# Altium schematic sheet Motor-Y.SchDoc(Motor-Y)
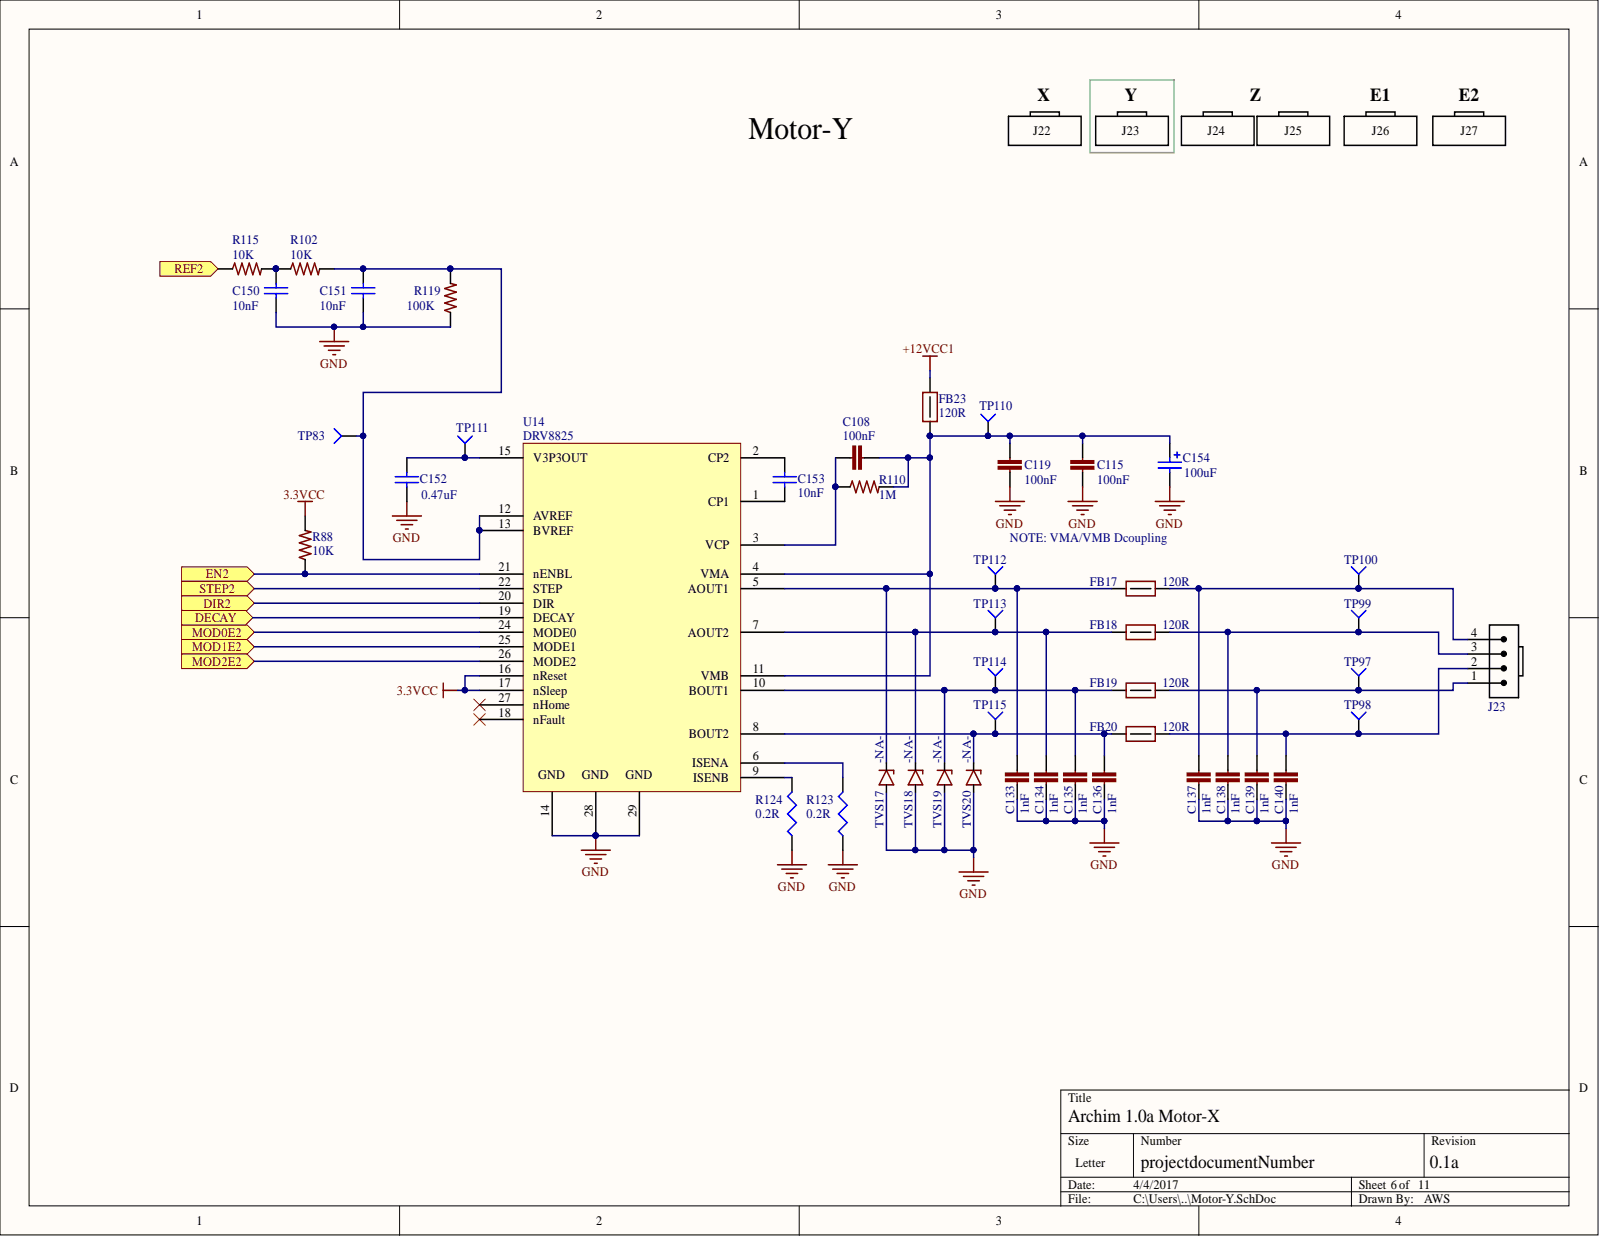
[diagram p0: Motor-Y.SchDoc]

## Parts
C108  100nF  [C0402]
C115  100nF  [C0603]
C119  100nF  [C0603]
C133  1nF  [C0402]
C134  1nF  [C0402]
C135  1nF  [C0402]
C136  1nF  [C0402]
C137  1nF  [C0402]
C138  1nF  [C0402]
C139  1nF  [C0402]
C140  1nF  [C0402]
C150  10nF  [C0402]
C151  10nF  [C0402]
C152  value 0.47uF  [C0402]  (hidden comment: 0402)
C153  10nF  [C0402]
C154  value 100uF  [CAP-ELEC-063063077]  (hidden comment: UWT1V101MCL1GS)
FB17  120R  [FB0603]
FB18  120R  [FB0603]
FB19  120R  [FB0603]
FB20  120R  [FB0603]
FB23  120R  [FB0603]
J23  Molex 0705430003  [4POL254]  (value not drawn)
R88  10K  [R0402]
R102  10K  [R0402]
R110  1M  [R0402]
R115  10K  [R0402]
R119  100K  [R0402]
R123  value 0.2R  [2512R-0.55]  (hidden comment: 2512)
R124  value 0.2R  [2512R-0.55]  (hidden comment: 2512)
TP83  TP4  [TP-2]  (value not drawn)  (no BOM)
TP97  TP4  [TP-2]  (value not drawn)  (no BOM)
TP98  TP4  [TP-2]  (value not drawn)  (no BOM)
TP99  TP4  [TP-2]  (value not drawn)  (no BOM)
TP100  TP4  [TP-2]  (value not drawn)  (no BOM)
TP110  TP4  [TP-2]  (value not drawn)  (no BOM)
TP111  TP4  [TP-2]  (value not drawn)  (no BOM)
TP112  TP4  [TP-2]  (value not drawn)  (no BOM)
TP113  TP4  [TP-2]  (value not drawn)  (no BOM)
TP114  TP4  [TP-2]  (value not drawn)  (no BOM)
TP115  TP4  [TP-2]  (value not drawn)  (no BOM)
TVS17  value -NA-  [SMF]  (hidden comment: TVS 30V SMF)
TVS18  value -NA-  [SMF]  (hidden comment: TVS 30V SMF)
TVS19  value -NA-  [SMF]  (hidden comment: TVS 30V SMF)
TVS20  value -NA-  [SMF]  (hidden comment: TVS 30V SMF)
U14  DRV8825  [DRV8825PWPR]

## Ports
DECAY, DIR2, EN2, MOD0E2, MOD1E2, MOD2E2, REF2, STEP2

## Nets
3.3VCC: R88.2 U14.16(nReset) U14.17(nSleep)
+12VCC1: FB23.2
GND: C115.2 C119.2 C133.2 C134.2 C135.2 C136.2 C137.2 C138.2 C139.2 C140.2 C150.1 C151.1 C152.1 C154.2 R119.1 R123.1 R124.1 TVS17.A TVS18.A TVS19.A TVS20.A U14.14 U14.28 U14.29
DECAY: U14.19(DECAY) ; port DECAY
DIR2: U14.20(DIR) ; port DIR2
EN2: R88.1 U14.21(nENBL) ; port EN2
MOD0E2: U14.24(MODE0) ; port MOD0E2
MOD1E2: U14.25(MODE1) ; port MOD1E2
MOD2E2: U14.26(MODE2) ; port MOD2E2
REF2: R115.1 ; port REF2
STEP2: U14.22(STEP) ; port STEP2
NetC108_1: C108.1 R110.1 U14.3(VCP)
NetC108_2: C108.2 C115.1 C119.1 C154.1 FB23.1 R110.2 TP110.1 U14.4(VMA) U14.11(VMB)
NetC133_1: C133.1 FB17.1 TP112.1 TVS17.K U14.5(AOUT1)
NetC134_1: C134.1 FB18.1 TP113.1 TVS18.K U14.7(AOUT2)
NetC135_1: C135.1 FB19.1 TP114.1 TVS19.K U14.10(BOUT1)
NetC136_1: C136.1 FB20.1 TP115.1 TVS20.K U14.8(BOUT2)
NetC137_1: C137.1 FB17.2 J23.4 TP100.1
NetC138_1: C138.1 FB18.2 J23.3 TP99.1
NetC139_1: C139.1 FB19.2 J23.1 TP97.1
NetC140_1: C140.1 FB20.2 J23.2 TP98.1
NetC150_2: C150.2 R102.1 R115.2
NetC151_2: C151.2 R102.2 R119.2 TP83.1 U14.12(AVREF) U14.13(BVREF)
NetC152_2: C152.2 TP111.1 U14.15(V3P3OUT)
NetC153_1: C153.1 U14.1(CP1)
NetC153_2: C153.2 U14.2(CP2)
NetR123_2: R123.2 U14.6(ISENA)
NetR124_2: R124.2 U14.9(ISENB)

Not connected: U14 18(nFault) 27(nHome)

## Library data of the parts
C108 = GRM155R71C104KA88D: CAP CER 0402 100nF 16V 10% X7R; PART DESCRIPTION: 0.10µF ±10% 16V Ceramic Capacitor X7R 0402 (1005 Metric); PART: 0.1uF 16V X7R 0402; MANUFACTURER: Murata; VALUE: 100nF; TOLERANCE: 10%; Simulation: Model Name; Signal Integrity: Model Name; MANUFACTURER #: GRM155R71C104KA88D; Library Name: 3Delight.SCHLIB; EE_SPEC: 16V; DISTRIBUTOR #: 490-3261-2-ND; DISTRIBUTOR: Digikey; Component Kind: Standard
C115 C119 = C0603C104K5RACTU: CAP CER 0603 100nF 50V 10% X7R; PART DESCRIPTION: 0.10µF ±10% 50V Ceramic Capacitor X7R 0603 (1608 Metric); PART: 100nF 50V X7R 0603; MANUFACTURER: Kemet; VALUE: 100nF; TOLERANCE: 10%; Simulation: Model Name; Signal Integrity: Model Name; MANUFACTURER #: C0603C104K5RACTU; Library Name: 3Delight.SCHLIB; EE_SPEC: 50V; DISTRIBUTOR #: 399-5089-2-ND; DISTRIBUTOR: Digikey; Component Kind: Standard
C133-C140 = CAP 1nF 50V 0402 X7R: 1000pF 50V Ceramic Capacitor X7R 0402 (1005 Metric) 0.039" L x 0.020" W (1.00mm x 0.50mm); PART DESCRIPTION: 1000pF ±10% 50V Ceramic Capacitor X7R 0402 (1005 Metric); PART: 1nF 50V X7R 0402; MANUFACTURER #: GRM155R71H102KA01D; MANUFACTURER: Murata; TOLERANCE: 10%; VALUE: 1nF; Library Name: 3Delight.SCHLIB; EE_SPEC: 50V; DISTRIBUTOR #: 490-1303-2-ND; DISTRIBUTOR: Digikey; Component Kind: Standard
C150 C151 C153 = GRM155R71H103JA88D: CAP CER 10nF 50V X7R 0402; PART DESCRIPTION: 10000pF ±5% 50V Ceramic Capacitor X7R 0402 (1005 Metric); MANUFACTURER: Murata; TOLERANCE: 5%; VALUE: 10nF; Simulation: CAP; Signal Integrity: Cap Semi; PART: 10nF 50V X7R 0402; MANUFACTURER #: GRM155R71H103JA88D; Library Name: Tigershark3D.SchLib; EE_SPEC: 50V; DISTRIBUTOR #: 490-7763-1-ND; DISTRIBUTOR: Digikey; Component Kind: Standard
C152 = GRM155R60J474KE19D: 0.47uF 6.3v C0402 - GRM155R60J474KE19D; PART DESCRIPTION: 0.47µF ±10% 6.3V Ceramic Capacitor X5R 0402 (1005 Metric); PART: 0.47uF 6.3V X5R 0402; MANUFACTURER: Murata; TOLERANCE: 10%; Simulation: CAP; Signal Integrity: Cap Semi; MANUFACTURER #: GRM155R60J474KE19D; Library Name: Tigershark3D.SchLib; EE_SPEC: 6.3V; DISTRIBUTOR #: 490-3266-2-ND; DISTRIBUTOR: Digikey; Component Kind: Standard
C154 = UWT1V101MCL1GS: CAP ALUM 100UF 35V 20% SMD; PART DESCRIPTION: 100µF 35V Aluminum Capacitors Radial, Can - SMD 1000 Hrs @ 105°C; PART: 100uF 35V SMD Al-El; MANUFACTURER: Nichicon; TOLERANCE: 20%; Simulation: CAP; Signal Integrity: Cap Pol; MANUFACTURER #: UWT1V101MCL1GS; Library Name: 3Delight.SCHLIB; EE_SPEC: 35V; DISTRIBUTOR #: 493-2203-2-ND; DISTRIBUTOR: Digikey; Component Kind: Standard
FB17-FB20 FB23 = FERRITE 120R 3A 0603: FERRITE BEAD 120 OHM 0603 1LN; MANUFACTURER #: CIS10P121AC; MANUFACTURER2#: 490-5254-2-ND; MANUFACTURER: Samsung; VALUE: 120Rfb; TOLERANCE: -NA-; EE_SPEC: 3A; PART DESCRIPTION: FERRITE BEAD 120 OHM 0603 1LN; PART: FERRITE 120R 3A 0603; Library Name: 3Delight.SCHLIB; DISTRIBUTOR #: 1276-6351-2-ND; DISTRIBUTOR: Digikey; Component Kind: Standard
J23 = Molex 0705430003 Alt_1: Molex 4 Pin Clip Connector; PART DESCRIPTION: 4 Positions Header, Shrouded Connector 0.100" (2.54mm) Through Hole Tin; PART: 4 Pin Conn; MANUFACTURER #: 0705430038; VALUE: HDR4; TOLERANCE: -NA-; MANUFACTURER: Molex; EE_SPEC: -NA-; DISTRIBUTOR #: WM4826-ND; DISTRIBUTOR: Digikey; Component Kind: Standard; Library Name: Archim.SCHLIB
R88 R102 R115 = RC0402FR-0710KP: RES SMD 10K OHM 1% 1/16W 0402; PART DESCRIPTION: 10k Ohm ±1% 0.063W, 1/16W Chip Resistor 0402 (1005 Metric) Moisture Resistant Thick Film; PART: 10k 1% 0402; MANUFACTURER: Yageo; VALUE: 10K; TOLERANCE: 1%; Simulation: Model Name; Signal Integrity: Model Name; MANUFACTURER #: RC0402FR-0710KP; Library Name: 3Delight.SCHLIB; EE_SPEC: 1/16W; DISTRIBUTOR #: YAG1249TR-ND; DISTRIBUTOR: Digikey; Component Kind: Standard
R110 = ERJ2GEJ105X: RES 0402 1M 100mW 5%; PART DESCRIPTION: 1M Ohm ±5% 0.1W, 1/10W Chip Resistor 0402 (1005 Metric) Automotive AEC-Q200 Thick Film; PART: 1M 5% 0402; MANUFACTURER: Panasonic; EE_SPEC: 1/10W; VALUE: 1M; TOLERANCE: 5%; Simulation: Model Name; Signal Integrity: Model Name; MANUFACTURER #: ERJ2GEJ105X; Library Name: Tigershark3D.SchLib; DISTRIBUTOR #: P1.0MJTR-ND; DISTRIBUTOR: Digikey; Component Kind: Standard
R119 = RC1005F104CS: RES SMD 100K OHM 1% 1/16W 0402; PART DESCRIPTION: 100k Ohm ±1% 0.063W, 1/16W Chip Resistor 0402 (1005 Metric) Moisture Resistant Thick Film; PART: 100k 1% 0402; MANUFACTURER: Samsung; VALUE: 100K; TOLERANCE: 1%; Simulation: Model Name; Signal Integrity: Model Name; MANUFACTURER #: RC1005F104CS; Library Name: Tigershark3D.SchLib; EE_SPEC: 1/16W; DISTRIBUTOR #: 1276-3432-1-ND; DISTRIBUTOR: Digikey; Component Kind: Standard
R123 R124 = CSRN2512FTR200: RES SMD 0.2 OHM 1% 2W 2512; PART DESCRIPTION: 0.2 Ohm ±1% 2W Chip Resistor 2512 (6432 Metric) Current Sense Thick Film; PART: 0.2R 1% 2512; MANUFACTURER #: CSRN2512FKR200; MANUFACTURER: Stackpole ELectronics Inc; TOLERANCE: 1%; Simulation: RESISTOR; Signal Integrity: Res; EE_SPEC: 2W; DISTRIBUTOR #: CSRN2512FKR200TR-ND; DISTRIBUTOR: Digikey; Component Kind: Standard; Library Name: Archim.SCHLIB
TP83 TP97-TP100 TP110-TP115 = Socket: MANUFACTURER #: NOTAPART-Testpoint; Signal Integrity: Connector; Description: Socket; Library Name: Archim.SCHLIB
TVS17-TVS20 = TVS 30V SMF: TVS DIODE 30VWM 48.4VC SMF; PART: TVS Diode 48.4VC; MANUFACTURER #: SMF30A-E3-08; MANUFACTURER: Vishay; EE_SPEC: 30Vrs 48.4Vc; TOLERANCE: -NA-; PART DESCRIPTION: TVS DIODE 30VWM 48.4VC SMF; Library Name: 3Delight.SCHLIB; DISTRIBUTOR #: SMF30A-E3-08GITR-ND; DISTRIBUTOR: Digikey; Component Kind: Standard
U14 = DRV8825: DRV8825 Ti Stepper Driver; PART DESCRIPTION: Bipolar Motor Driver Power MOSFET Step/Direction 28-HTSSOP; MANUFACTURER: Texas Instruments; VALUE: -NA-; EE_SPEC: 8.2-45VDC; TOLERANCE: -NA-; PART: DRV8825; MANUFACTURER #: DRV8825PWPR; Library Name: Tigershark3D.SchLib; DISTRIBUTOR #: 296-29503-2-ND; DISTRIBUTOR: Digikey; Component Kind: Standard
